# Altium schematic sheet voltage_monitor.SchDoc
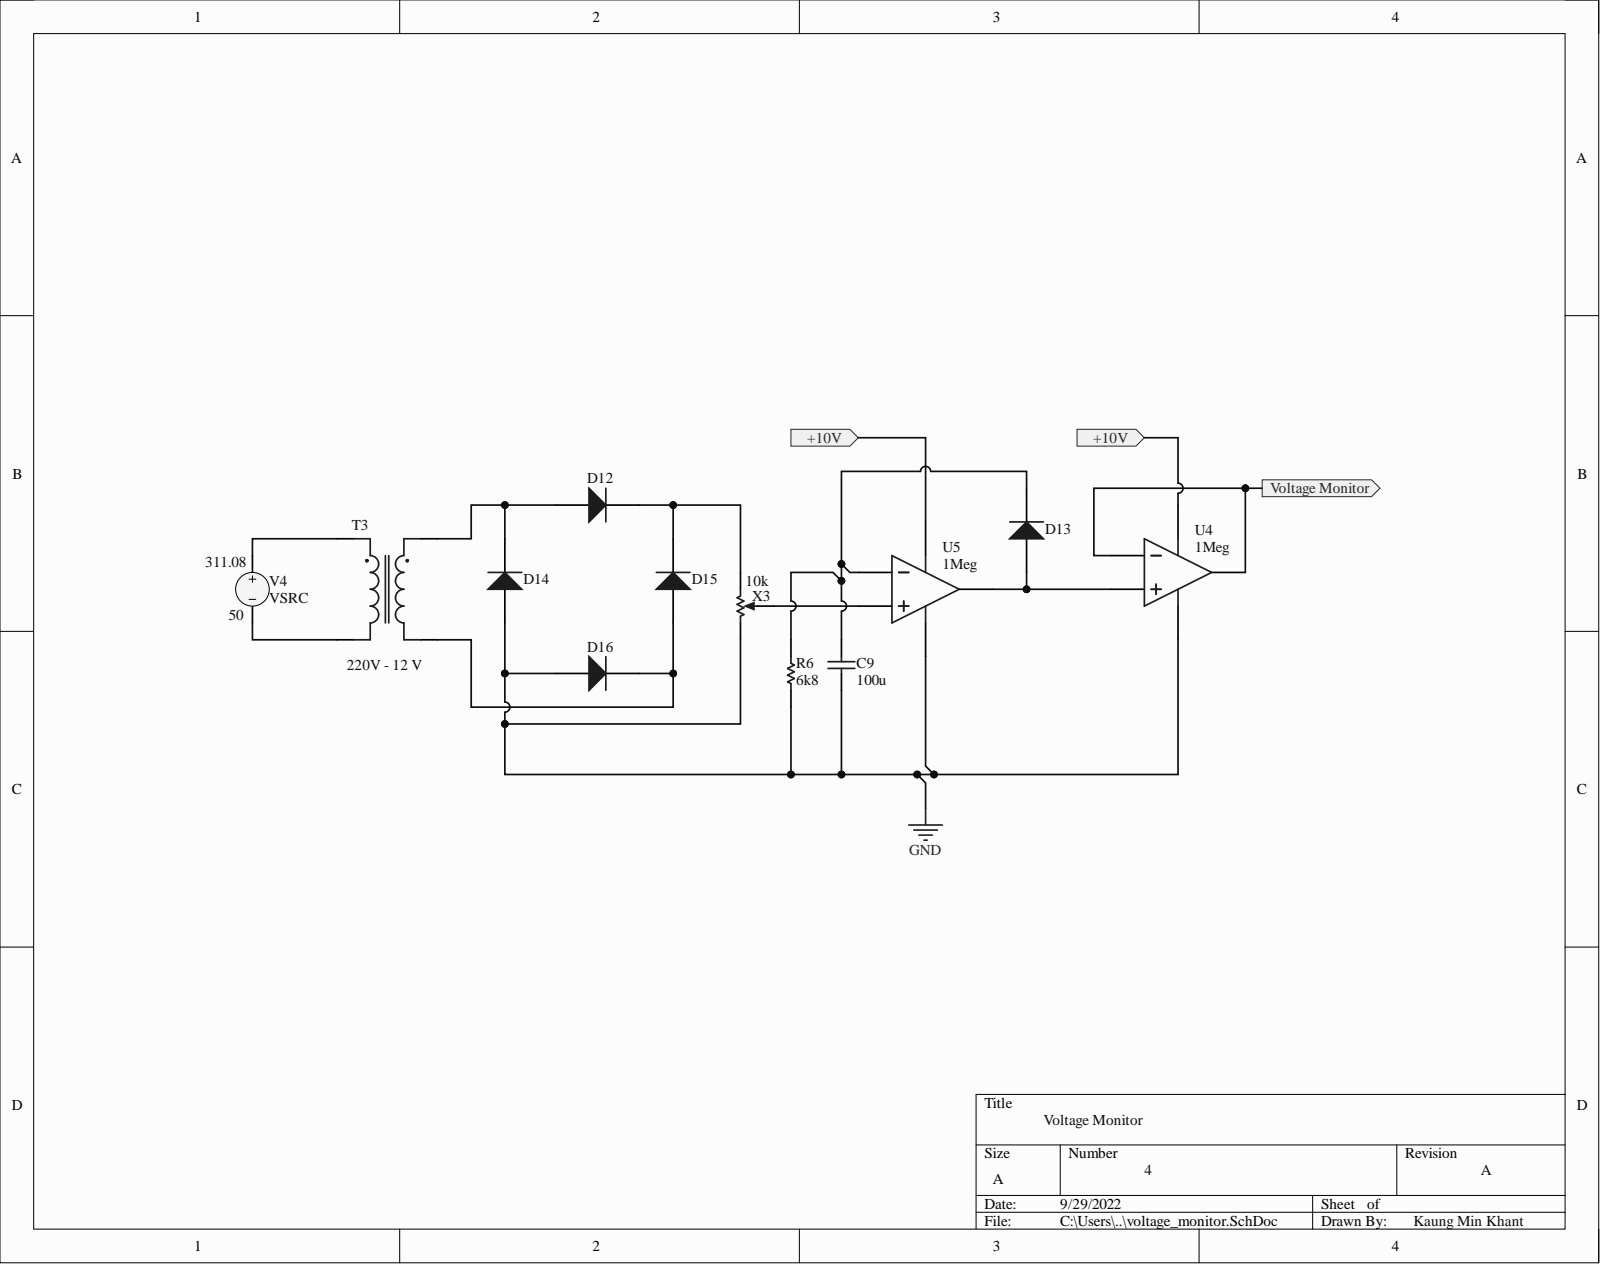
[diagram p0: voltage_monitor.SchDoc]

## Parts
C9  value 100u  (hidden comment: Capacitor)
D12  Diode  (value not drawn)
D13  Diode  (value not drawn)
D14  Diode  (value not drawn)
D15  Diode  (value not drawn)
D16  Diode  (value not drawn)
R6  value 6k8  (hidden comment: Resistor)
T3  220V - 12 V
U4  GAIN: 1Meg  (hidden comment: Ideal Operational Amplifier)
U5  GAIN: 1Meg  (hidden comment: Ideal Operational Amplifier)
V4  VSRC  Amplitude: 311.08
X3  value 10k  (hidden comment: Potentiometer)

## Ports
+10V, Voltage Monitor

## Nets
GND: C9.1 D14.1 D16.1 R6.1 U4.5 U5.5 X3.2
+10V: U4.4 U5.4 ; port +10V
Voltage Monitor: U4.1 U4.3 ; port Voltage Monitor
NetC9_2: C9.2 D13.2 R6.2 U5.1
NetD12_1: D12.1 D14.2 T3.3
NetD12_2: D12.2 D15.2 X3.1
NetD13_1: D13.1 U4.2 U5.3
NetD15_1: D15.1 D16.2 T3.4
NetT3_1: T3.1 V4.1
NetT3_2: T3.2 V4.2
NetU5_2: U5.2 X3.3

## Library data of the parts
C9 = Capacitor: SimulationGenericComponent: True; Component Kind: Standard; Description: Capacitor; Library Name: Simulation Generic Components.IntLib; Simulation: C_Capacitor_Default
D12-D16 = Diode: AF: 1; BV: 0; BV_MAX: 1e99; EG: 1.11; FC: 0.5; IBV: 0.001; IS: 1e-14; MJSW: 0.33; NTUN: 30; SimulationGenericComponent: True; TNOM: 27; XTI: 3; CJO: 0; CJP: 0; Component Kind: Standard; CTA: 0; CTP: 0; Description: Diode; FCS: 0.5; FV_MAX: 1e99; IKF: 0; IKR: 0; ISR: 1e-14; JSW: 0; JTUN: 0; JTUNSW: 0; KEG: 1; KF: 0; LEVEL: 1; Library Name: Simulation Generic Components.IntLib; N: 1; NBV: 1; NR: 1; NS: 1; PHP: 1; RS: 0; Simulation: diode; TCV: 0; TLEV: 0; TLEVC: 0; TM1: 0; TM2: 0; TPB: 0; TPHP: 0; TRS: 0; TRS2: 0; TT: 0; TTT1: 0; TTT2: 0; VJ: 1; XTITUN: 3
R6 = Resistor: SimulationGenericComponent: True; TC1: 0; Component Kind: Standard; Description: Resistor; Library Name: Simulation Generic Components.IntLib; Simulation: R_Resistor_Default; TC2: 0
T3 = Transformer Ideal: Ideal Transformer; GAIN: 1; SimulationGenericComponent: True; Component Kind: Standard; Library Name: Simulation Generic Components.IntLib; Simulation: IDEAL_TRANSFORMER
U4 U5 = OpAmp with power terminals: SimulationGenericComponent: True; Component Kind: Standard; Description: Ideal Operational Amplifier; Library Name: Simulation Generic Components.IntLib; Simulation: IDEALOPAMP_5NODES
V4 = VSRC: Voltage Source; AC Magnitude: 1; AC Phase: 0; DC Magnitude: 5; Frequency: 50; Note: PCB Footprint - Not required; Component Kind: Standard; Damping Factor: 0; Delay: 0; LatestRevisionDate: 30-July-2020; LatestRevisionNote: Re-released for DXP Platform.; Library Name: Simulation Generic Components.IntLib; Offset: 0; PackageReference: Not Applicable; Phase: 0; Published: 30-July-2020; Simulation: VSRC; Publisher: Altium Limited
X3 = Potentiometer: position: 0.5; SimulationGenericComponent: True; Component Kind: Standard; Description: Potentiometer; Library Name: Simulation Generic Components.IntLib; Simulation: POT
